# Altium schematic sheet CHIPHOME Y17BP01 STM32.SchDoc
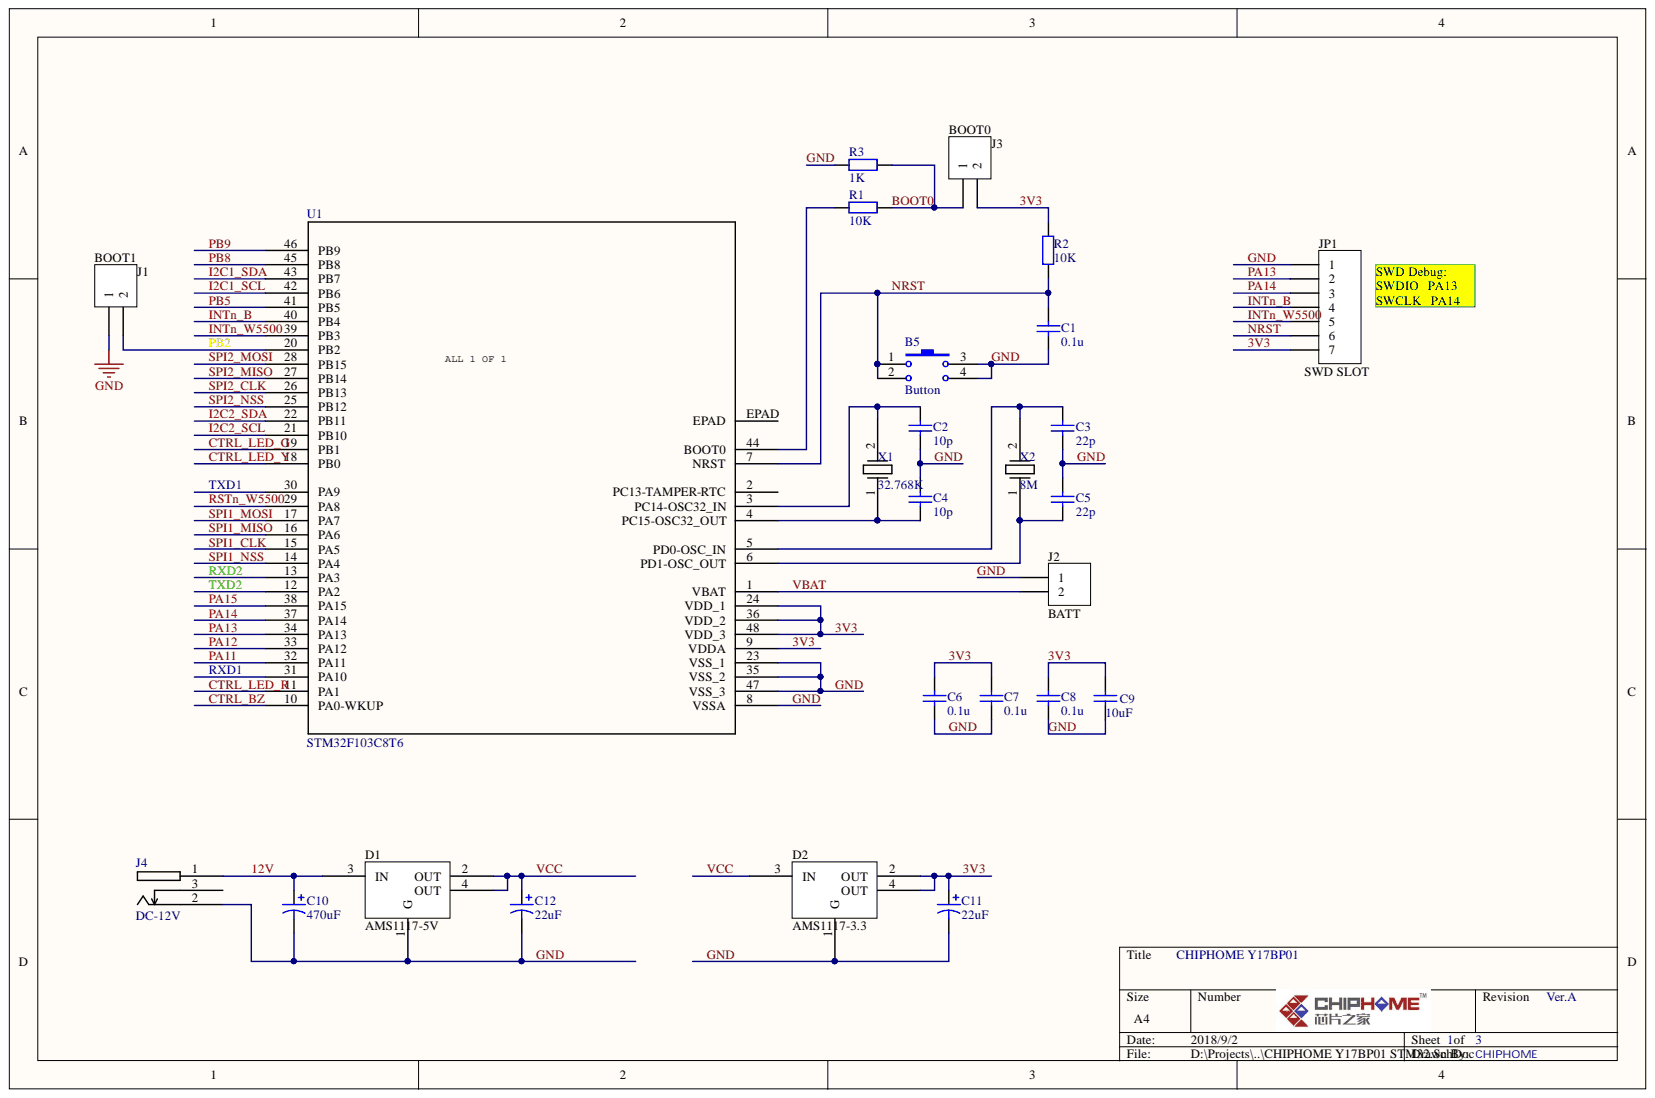
[diagram p0: CHIPHOME Y17BP01 STM32.SchDoc]

## Parts
B5  Button  [Button_4P]
C1  0.1u  [sc0603a]
C2  10p  [sc0603a]
C3  22p  [sc0603a]
C4  10p  [sc0603a]
C5  22p  [sc0603a]
C6  0.1u  [sc0603a]
C7  0.1u  [sc0603a]
C8  0.1u  [sc0603a]
C9  10uF  [sc0805a]
C10  470uF  [cap-dia8mm]
C11  22uF  [cap-dia4mm]
C12  22uF  [cap-dia4mm]
D1  AMS1117-5V  [SOT-223]
D2  AMS1117-3.3  [SOT-223]
J1  BOOT1  [HDR1X2]
J2  BATT  [HDR1X2]
J3  BOOT0  [HDR1X2]
J4  DC-12V  [pwc3-0914a]
JP1  SWD SLOT  [HDR1X7]
R1  10K  [sr0603a]
R2  10K  [sr0603a]
R3  1K  [sr0603a]
U1  STM32F103C8T6  [qfp48-20-0909a]
X1  32.768K  [sx2-0412a]
X2  8M  [sx2-0412a]

## Nets
3V3: C6.1 C7.1 C8.1 C9.2 C11.1 D2.2(OUT) D2.4(OUT) J3.2 JP1.7 R2.2 U1.9(VDDA) U1.24(VDD_1) U1.36(VDD_2) U1.48(VDD_3)
12V: C10.1 D1.3(IN) J4.1
BOOT0: J3.1 R1.2 R3.1
CTRL_BZ: U1.10(PA0-WKUP)
CTRL_LED_G: U1.19(PB1)
CTRL_LED_R: U1.11(PA1)
CTRL_LED_Y: U1.18(PB0)
GND: B5.3 B5.4 C1.2 C2.2 C3.2 C4.1 C5.1 C6.2 C7.2 C8.2 C9.1 C10.2 C11.2 C12.2 D1.1(G) D2.1(G) J1.1 J2.1 J4.2 JP1.1 R3.2 U1.8(VSSA) U1.23(VSS_1) U1.35(VSS_2) U1.47(VSS_3)
I2C1_SCL: U1.42(PB6)
I2C1_SDA: U1.43(PB7)
I2C2_SCL: U1.21(PB10)
I2C2_SDA: U1.22(PB11)
INTn_B: JP1.4 U1.40(PB4)
INTn_W5500: JP1.5 U1.39(PB3)
NRST: B5.1 B5.2 C1.1 JP1.6 R2.1 U1.7(NRST)
PA11: U1.32(PA11)
PA12: U1.33(PA12)
PA13: JP1.2 U1.34(PA13)
PA14: JP1.3 U1.37(PA14)
PA15: U1.38(PA15)
PB2: J1.2 U1.20(PB2)
PB5: U1.41(PB5)
PB8: U1.45(PB8)
PB9: U1.46(PB9)
RSTn_W5500: U1.29(PA8)
RXD1: U1.31(PA10)
RXD2: U1.13(PA3)
SPI1_CLK: U1.15(PA5)
SPI1_MISO: U1.16(PA6)
SPI1_MOSI: U1.17(PA7)
SPI1_NSS: U1.14(PA4)
SPI2_CLK: U1.26(PB13)
SPI2_MISO: U1.27(PB14)
SPI2_MOSI: U1.28(PB15)
SPI2_NSS: U1.25(PB12)
TXD1: U1.30(PA9)
TXD2: U1.12(PA2)
VBAT: J2.2 U1.1(VBAT)
VCC: C12.1 D1.2(OUT) D1.4(OUT) D2.3(IN)
NetC2_1: C2.1 U1.3(PC14-OSC32_IN) X1.2
NetC3_1: C3.1 U1.5(PD0-OSC_IN) X2.2
NetC4_2: C4.2 U1.4(PC15-OSC32_OUT) X1.1
NetC5_2: C5.2 U1.6(PD1-OSC_OUT) X2.1
NetR1_1: R1.1 U1.44(BOOT0)

Not connected: J4 3; U1 2(PC13-TAMPER-RTC) EPAD

## Library data of the parts
B5 = Component_1: Component Kind: Standard; Library Name: Button_4P_DIP.SchLib
C1-C9 = Cap: Capacitor; Component Kind: Standard; LatestRevisionDate: 17-Jul-2002; LatestRevisionNote: Re-released for DXP Platform.; Library Name: Miscellaneous Devices.IntLib; PackageReference: RAD-0.3; Published: 8-Jun-2000; Signal Integrity: Cap; Simulation: CAP; Publisher: Altium Limited
  C1 C6-C8: Value: 0.1u
  C2 C4: Value: 10p
  C3 C5: Value: 22p
  C9: Value: 10uF
C10 = Cap Pol1: Polarized Capacitor (Radial); Value: 22uF; Component Kind: Standard; LatestRevisionDate: 17-Jul-2002; LatestRevisionNote: Re-released for DXP Platform.; Library Name: Miscellaneous Devices.IntLib; PackageReference: RB7.6-15; Published: 8-Jun-2000; Signal Integrity: Cap Pol; Simulation: CAP; Publisher: Altium Limited
C11 C12 = Cap Pol1: Polarized Capacitor (Radial); Component Kind: Standard; LatestRevisionDate: 17-Jul-2002; LatestRevisionNote: Re-released for DXP Platform.; Library Name: Miscellaneous Devices.IntLib; PackageReference: RB7.6-15; Published: 8-Jun-2000; Signal Integrity: Cap Pol; Simulation: CAP; Value: 22uF; Publisher: Altium Limited
D1 = AMS1117-3.3: Component Kind: Standard; Library Name: MyLib.SchLib
J1-J3 = Header 2: Header, 2-Pin; Component Kind: Standard; LatestRevisionDate: 17-Jul-2002; LatestRevisionNote: Re-released for DXP Platform.; Library Name: Miscellaneous Connectors.IntLib; Signal Integrity: Connector; Publisher: Altium Limited
J4 = PJ-002A: Imported; CHcode: CNTPP000001; Designer: Chiphome; Component Kind: Standard; Device: PJ-002A; Library Name: PJ-002A.SchLib; RefDes: RefDes; Type: DEV; Value: Value
JP1 = Header 7: Header, 7-Pin; Component Kind: Standard; LatestRevisionDate: 17-Jul-2002; LatestRevisionNote: Re-released for DXP Platform.; Library Name: Miscellaneous Connectors.IntLib; Signal Integrity: Connector; Publisher: Altium Limited
R1-R3 = Res2: Resistor; Component Kind: Standard; LatestRevisionDate: 17-Jul-2002; LatestRevisionNote: Re-released for DXP Platform.; Library Name: Miscellaneous Devices.IntLib; PackageReference: AXIAL-0.4; Published: 8-Jun-2000; Signal Integrity: Res; Simulation: RESISTOR; Publisher: Altium Limited
  R1 R2: Value: 10K
  R3: Value: 1K
U1 = STM32F103C8T6: Imported; CHcode: MCUS3020201; Designer: CH; Component Kind: Standard; Device: STM32F103C8T6; Library Name: stm32f103c8t6.schlib; RefDes: RefDes; Type: DEV; Value: Value
X1 X2 = ABL-12.000MHZ-B2: Imported; CHcode: XTLDP000001; Designer: Chiphome; Component Kind: Standard; Device: ABL-12.000MHZ-B2; Library Name: ABL-12.000MHZ-B2.SchLib; RefDes: RefDes; Type: DEV
  X1: Value: 32.768K
  X2: Value: 8M
D2 = AMS1117-3.3: Component Kind: Standard; Library Name: MyLib.SchLib
